# Altium schematic sheet Gate Driver Board.SchDoc("Gate Driver Board")
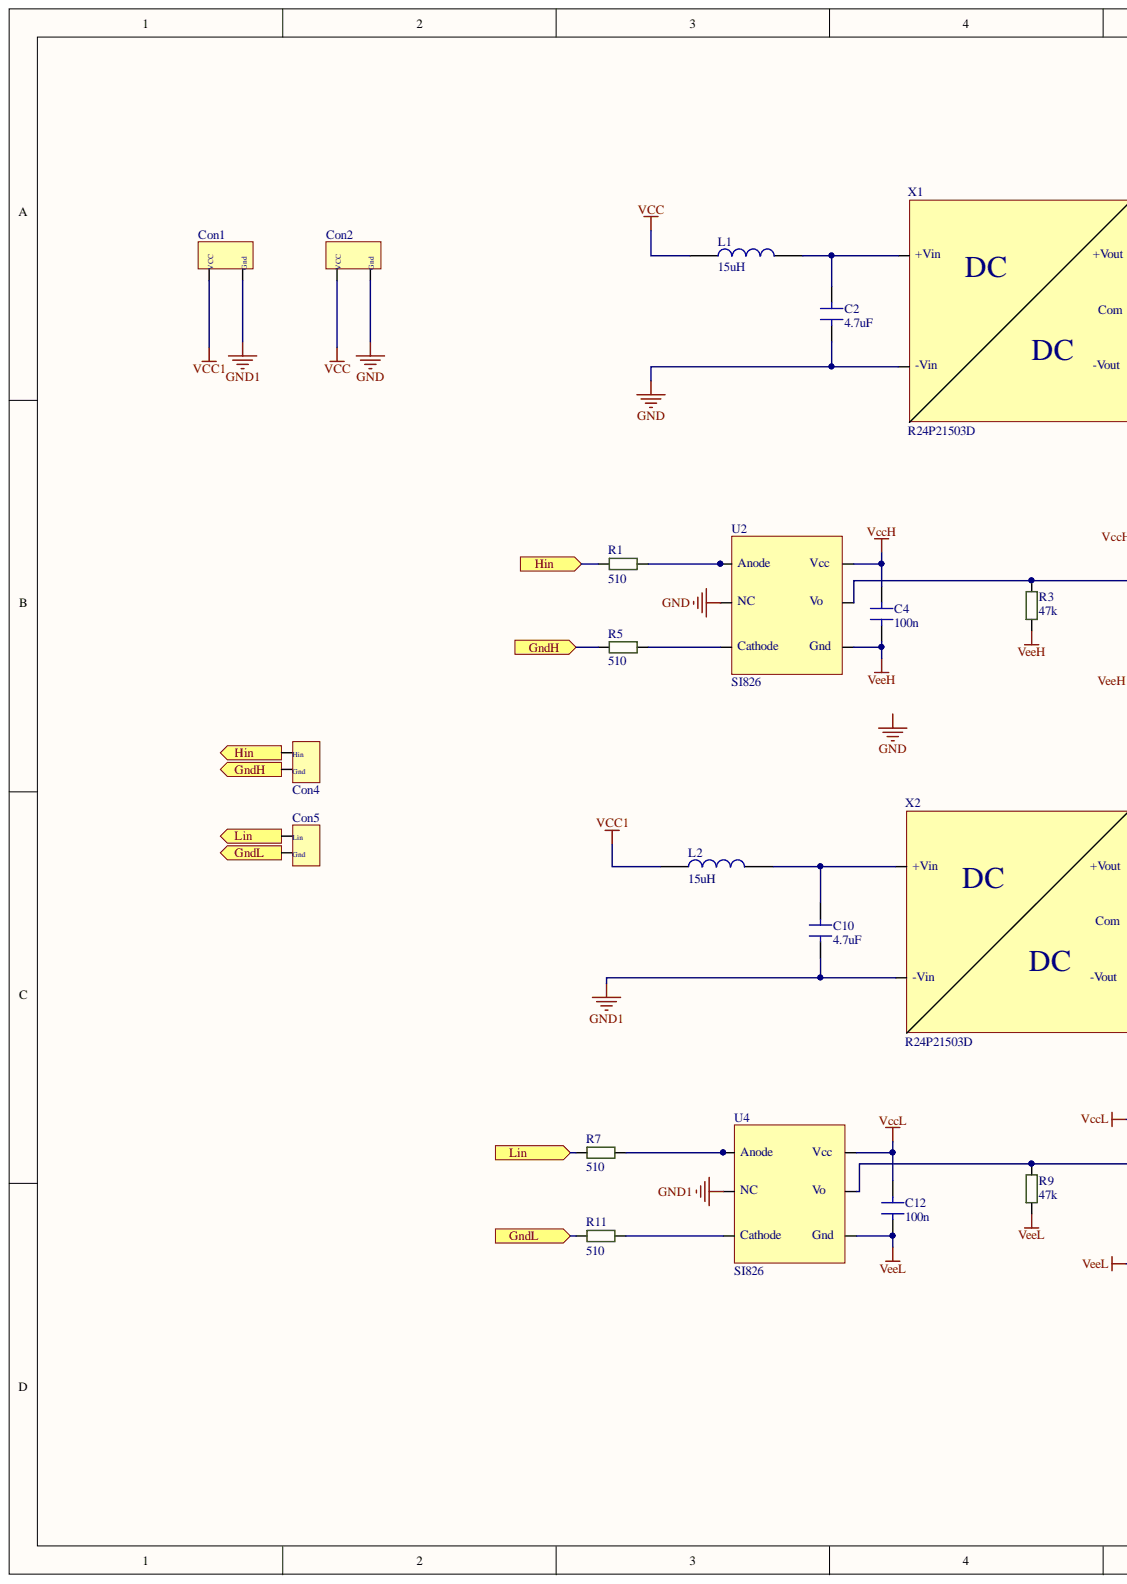
[diagram p0: Gate Driver Board.SchDoc, left]
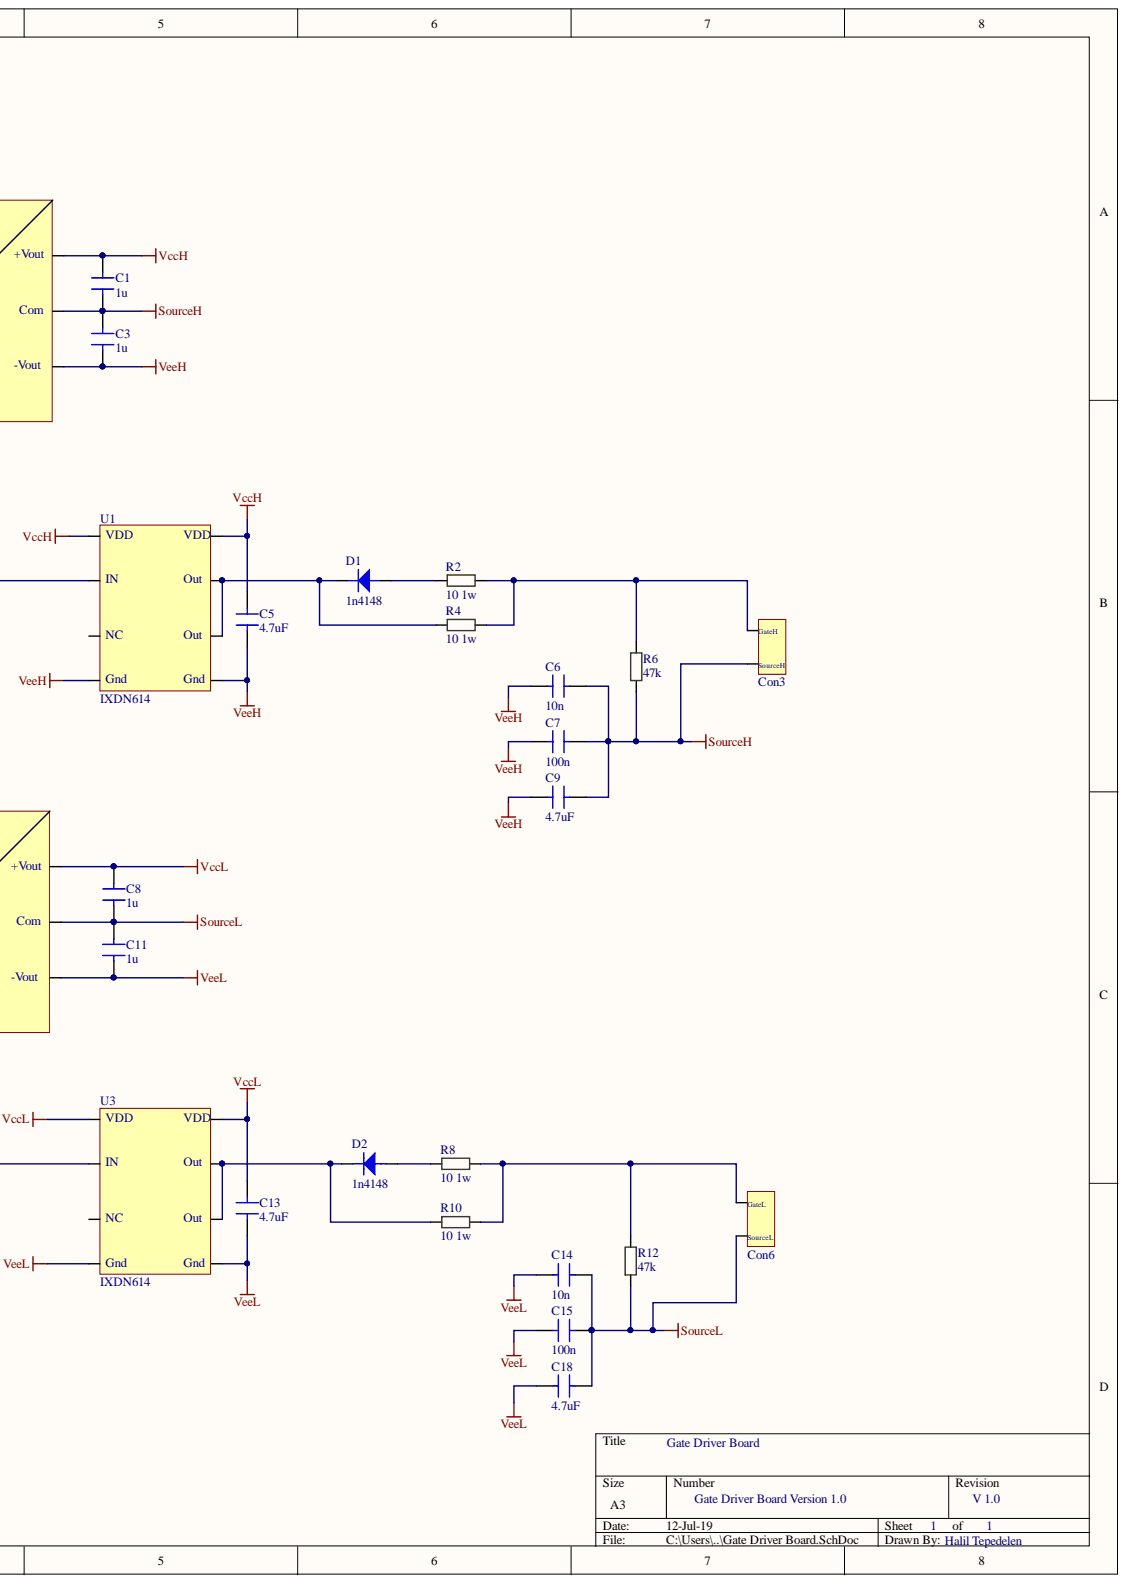
[diagram p1: Gate Driver Board.SchDoc, right]

## Parts
C1  1u  [C-0805]
C2  4.7uF  [C-0805]
C3  1u  [C-0805]
C4  100n  [C-0805]
C5  4.7uF  [C-1206]
C6  10n  [C-0805]
C7  100n  [C-0805]
C8  1u  [C-0805]
C9  4.7uF  [C-1206]
C10  4.7uF  [C-0805]
C11  1u  [C-0805]
C12  100n  [C-0805]
C13  4.7uF  [C-1206]
C14  10n  [C-0805]
C15  100n  [C-0805]
C18  4.7uF  [C-1206]
Con1  Connector  [Conn]  (value not drawn)
Con2  Connector  [Conn]  (value not drawn)
Con3  Connector2_1  [Conn]  (value not drawn)
Con4  Connector2  [Conn]  (value not drawn)
Con5  Connector3  [Conn]  (value not drawn)
Con6  Connector2_2  [Conn]  (value not drawn)
D1  1n4148  [SOD123]
D2  1n4148  [SOD123]
L1  15uH  [INDPM6063X300N]
L2  15uH  [INDPM6063X300N]
R1  510  [R-0805]
R2  10 1w  [R-2512]
R3  47k  [R-0805]
R4  10 1w  [R-2512]
R5  510  [R-0805]
R6  47k  [R-0805]
R7  510  [R-0805]
R8  10 1w  [R-2512]
R9  47k  [R-0805]
R10  10 1w  [R-2512]
R11  510  [R-0805]
R12  47k  [R-0805]
U1  IXDN614  [FAN3122 Soic8]
U2  SI826  [SOIC127P1150X265-6N]
U3  IXDN614  [FAN3122 Soic8]
U4  SI826  [SOIC127P1150X265-6N]
X1  R24P21503D  [SIP7]
X2  R24P21503D  [SIP7]

## Ports
GndH, GndL, Hin, Lin

## Nets
GND: C2.1 Con2.2 U2.2(NC) X1.2(-Vin)
GND1: C10.1 Con1.2 U4.2(NC) X2.2(-Vin)
SourceH: C1.1 C3.2 C6.1 C7.1 C9.2 Con3.2 R6.1 X1.6(Com)
SourceL: C8.1 C11.2 C14.1 C15.1 C18.2 Con6.2 R12.1 X2.6(Com)
VCC: Con2.1 L1.1
VCC1: Con1.1 L2.1
VccH: C1.2 C4.2 C5.2 U1.1(VDD) U1.8(VDD) U2.6(Vcc) X1.7(+Vout)
VccL: C8.2 C12.2 C13.2 U3.1(VDD) U3.8(VDD) U4.6(Vcc) X2.7(+Vout)
VeeH: C3.1 C4.1 C5.1 C6.2 C7.2 C9.1 R3.1 U1.4(Gnd) U1.5(Gnd) U2.4(Gnd) X1.5(-Vout)
VeeL: C11.1 C12.1 C13.1 C14.2 C15.2 C18.1 R9.1 U3.4(Gnd) U3.5(Gnd) U4.4(Gnd) X2.5(-Vout)
GndH: Con4.2 R5.1 ; port GndH
GndL: Con5.2 R11.1 ; port GndL
Hin: Con4.1 R1.1 ; port Hin
Lin: Con5.1 R7.1 ; port Lin
NetC2_2: C2.2 L1.2 X1.1(+Vin)
NetC10_2: C10.2 L2.2 X2.1(+Vin)
NetCon3_1: Con3.1 R2.2 R4.2 R6.2
NetCon6_1: Con6.1 R8.2 R10.2 R12.2
NetD1_a: D1.a R2.1
NetD1_k: D1.k R4.1 U1.6(Out) U1.7(Out)
NetD2_a: D2.a R8.1
NetD2_k: D2.k R10.1 U3.6(Out) U3.7(Out)
NetR1_2: R1.2 U2.1(Anode)
NetR3_2: R3.2 U1.2(IN) U2.5(Vo)
NetR5_2: R5.2 U2.3(Cathode)
NetR7_2: R7.2 U4.1(Anode)
NetR9_2: R9.2 U3.2(IN) U4.5(Vo)
NetR11_2: R11.2 U4.3(Cathode)

Not connected: U1 3(NC); U3 3(NC)

## Library data of the parts
C1-C4 C6-C8 C10-C12 C14 C15 = C-0805: Library Name: Gate Driver Board.SchLib; Component Kind: Standard
C5 C13 = C-1206: Library Name: Gate Driver Board.SchLib; Component Kind: Standard
C9 C18 = Cap1206: Library Name: Gate Driver Board.SchLib; Component Kind: Standard
D1 D2 = 1N4148W-E3-18: Diode Small Signal Switching 100V 0.15A 2-Pin SOD-123 T/R; Manufacturer: Vishay; Reverse Recovery Time: 4ns; Power Dissipation: 350mW; Peak Reverse Current: 100uA; Peak Non-Repetitive Surge Current: 2A; Max Reverse Voltage (DC): 75V; Max Reverse Leakage Current: 5uA; Forward Voltage: 1.2V; Forward Current: 150mA; Element Configuration: Single; Width: 1.7mm; Weight: 0.000363oz; Supplier Part Number 1: 70061597; Supplier 1: Allied Electronics; Stock 1: 6838; RoHS: Yes; Recovery Time: 4ns; Radiation Hardening: No; Pricing 1: 1 = 0.03900, 25 = 0.03800, 100 = 0.03600, 250 = 0.03400, 500 = 0.03200, 1000 = 0.03100, 2500 = 0.02900 (USD); Packaging: Tape and Reel; Package Quantity: 10000; Number of Pins: 2; Mount: Surface Mount; Min Operating Temperature: -55°C; Max Surge Current: 2A; Max Repetitive Reverse Voltage (Vrrm): 100V; Max Power Dissipation: 350mW; Max Operating Temperature: 150°C; Manufacturer Part Number: 1N4148W-E3-18; Library Name: Gate Driver Board.SchLib; Length: 2.85mm; Lead Free: Lead Free; Height: 1.25mm; Contact Plating: Tin; ComponentLink4URL: https://datasheet.ciiva.com/29593/1n4148w-29593112.pdf?src-supplier=Digi-Key; ComponentLink4Description: https://datasheet.ciiva.com/29593/1n4148w-29593112.pdf?src-supplier=Digi-Key; ComponentLink3URL: https://datasheet.ciiva.com/29593/1n4148w-29593111.pdf?src-supplier=Digi-Key; ComponentLink3Description: https://datasheet.ciiva.com/29593/1n4148w-29593111.pdf?src-supplier=Digi-Key; ComponentLink2URL: https://datasheet.ciiva.com/29593/1n4148w-29593113.pdf?src-supplier=Digi-Key; ComponentLink2Description: https://datasheet.ciiva.com/29593/1n4148w-29593113.pdf?src-supplier=Digi-Key; ComponentLink1URL: https://datasheet.ciiva.com/29885/1n4148w-29885941.pdf?src-supplier=Avnet; ComponentLink1Description: https://datasheet.ciiva.com/29885/1n4148w-29885941.pdf?src-supplier=Avnet; Component Kind: Standard; Average Rectified Current: 150mA
L1 L2 = inductor: Library Name: Gate Driver Board.SchLib; Component Kind: Standard
R1 R3 R5-R7 R9 R11 R12 = Res0805: Library Name: Gate Driver Board.SchLib; Component Kind: Standard
R2 R4 R8 R10 = R-2512: Library Name: Gate Driver Board.SchLib; Description: 10 1w; Component Kind: Standard
U1 U3 = IXDN614SITR: 14A 8SOIC EXP MTL NON INVERTING; Manufacturer: Ixys Clare; Rise Time: 35ns; Propagation Delay: 70ns; Number of Outputs: 1; Min Supply Voltage: 4.5V; Max Supply Voltage: 35V; Fall Time: 25ns; Supplier Part Number 1: 849-IXDN614SITR; Supplier 1: Mouser; RoHS: Yes; Pricing 1: 1 = 4.24000, 10 = 3.79000, 50 = 3.41000, 100 = 3.10000, 1000 = 2.12000, 10000 = 1.90000 (USD); Packaging: Tape and Reel; Package Quantity: 2000; Number of Pins: 8; Number of Drivers: 1; Mount: Surface Mount; Min Operating Temperature: -40°C; Max Output Current: 14A; Max Operating Temperature: 125°C; Manufacturer Part Number: IXDN614SITR; Library Name: Gate Driver Board.SchLib; Lead Free: Lead Free; ComponentLink1URL: https://datasheet.ciiva.com/6613/ixd-614-6613145.pdf?src-supplier=Digi-Key; ComponentLink1Description: https://datasheet.ciiva.com/6613/ixd-614-6613145.pdf?src-supplier=Digi-Key; Component Kind: Standard; Case/Package: SOIC
U2 U4 = SI8261BCD-C-IS: Gate Drivers 5kV Opto input single Ch 2.5A drvr; Manufacturer: Silicon Labs; Turn-On Delay Time: 60ns; Rise Time: 5.5ns; Pulse Width Distortion (PWD): 10ns; Propagation Delay: 50ns; Output Voltage: 250mV; Output Current: 10mA; Number of Outputs: 2; Number of Drivers: 1; Nominal Supply Current: 2mA; Min Supply Voltage: 5V; Max Supply Voltage: 30V; Max Power Dissipation: 300mW; Max Output Current: 4A; Low Level Output Current: 500mA; High Level Output Current: 1.2A; Forward Voltage: 2.8V; Fall Time: 8.5ns; Approvals: CSA; Width: 11.5mm; Supplier Part Number 1: 336-2418-5-ND; Supplier 1: Digi-Key; Stock 1: 6966; RoHS: Yes; REACH SVHC: Unknown; Pricing 1: 1 = 1.95000, 25 = 1.88040, 100 = 1.80800, 1000 = 1.65000 (USD); Packaging: Rail/Tube; Package Quantity: 96; Number of Pins: 6; Number of Channels: 1; Min Operating Temperature: -40°C; Max Operating Temperature: 125°C; Manufacturer Part Number: SI8261BCD-C-IS; Library Name: Gate Driver Board.SchLib; Length: 4.58mm; Height: 2.05mm; Element Configuration: Single; ComponentLink3URL: https://datasheet.ciiva.com/46409/1816586-46409901.pdf?src-supplier=Element14; ComponentLink3Description: https://datasheet.ciiva.com/46409/1816586-46409901.pdf?src-supplier=Element14; ComponentLink2URL: https://datasheet.ciiva.com/18617/si826x-rev0-9-18617221.pdf?src-supplier=Digi-Key; ComponentLink2Description: https://datasheet.ciiva.com/18617/si826x-rev0-9-18617221.pdf?src-supplier=Digi-Key; ComponentLink1URL: https://datasheet.ciiva.com/30476/0900766b81299254-30476857.pdf?src-supplier=RSComponents; ComponentLink1Description: https://datasheet.ciiva.com/30476/0900766b81299254-30476857.pdf?src-supplier=RSComponents; Component Kind: Standard; Case/Package: SOIC
X1 X2 = R24P21503D: Manufacturer: Recom; Max Output Voltage: 15V; Width: 9.8mm; Weight: 4.3g; Supplier Part Number 1: 945-3071-5-ND; Supplier 1: Digi-Key; Stock 1: 378; RoHS: No; Pricing 1: 1 = 8.78000, 5 = 8.71200, 10 = 8.64500, 25 = 8.51200, 50 = 8.24600, 100 = 7.44800, 250 = 7.31500, 500 = 7.18200 (USD); Manufacturer Part Number: R24P21503D; Library Name: Gate Driver Board.SchLib; Length: 19.5mm; Height: 12.5mm; Component Kind: Standard
Con1 Con2 = Connector: Library Name: Gate Driver Board.SchLib; Component Kind: Standard
Con3 = Connector2_1: Library Name: Gate Driver Board.SchLib; Component Kind: Standard
Con4 = Connector2: Library Name: Gate Driver Board.SchLib; Component Kind: Standard
Con5 = Connector3: Library Name: Gate Driver Board.SchLib; Component Kind: Standard
Con6 = Connector2_2: Library Name: Gate Driver Board.SchLib; Component Kind: Standard
